# Altium schematic sheet RFID.SchDoc(RFID)
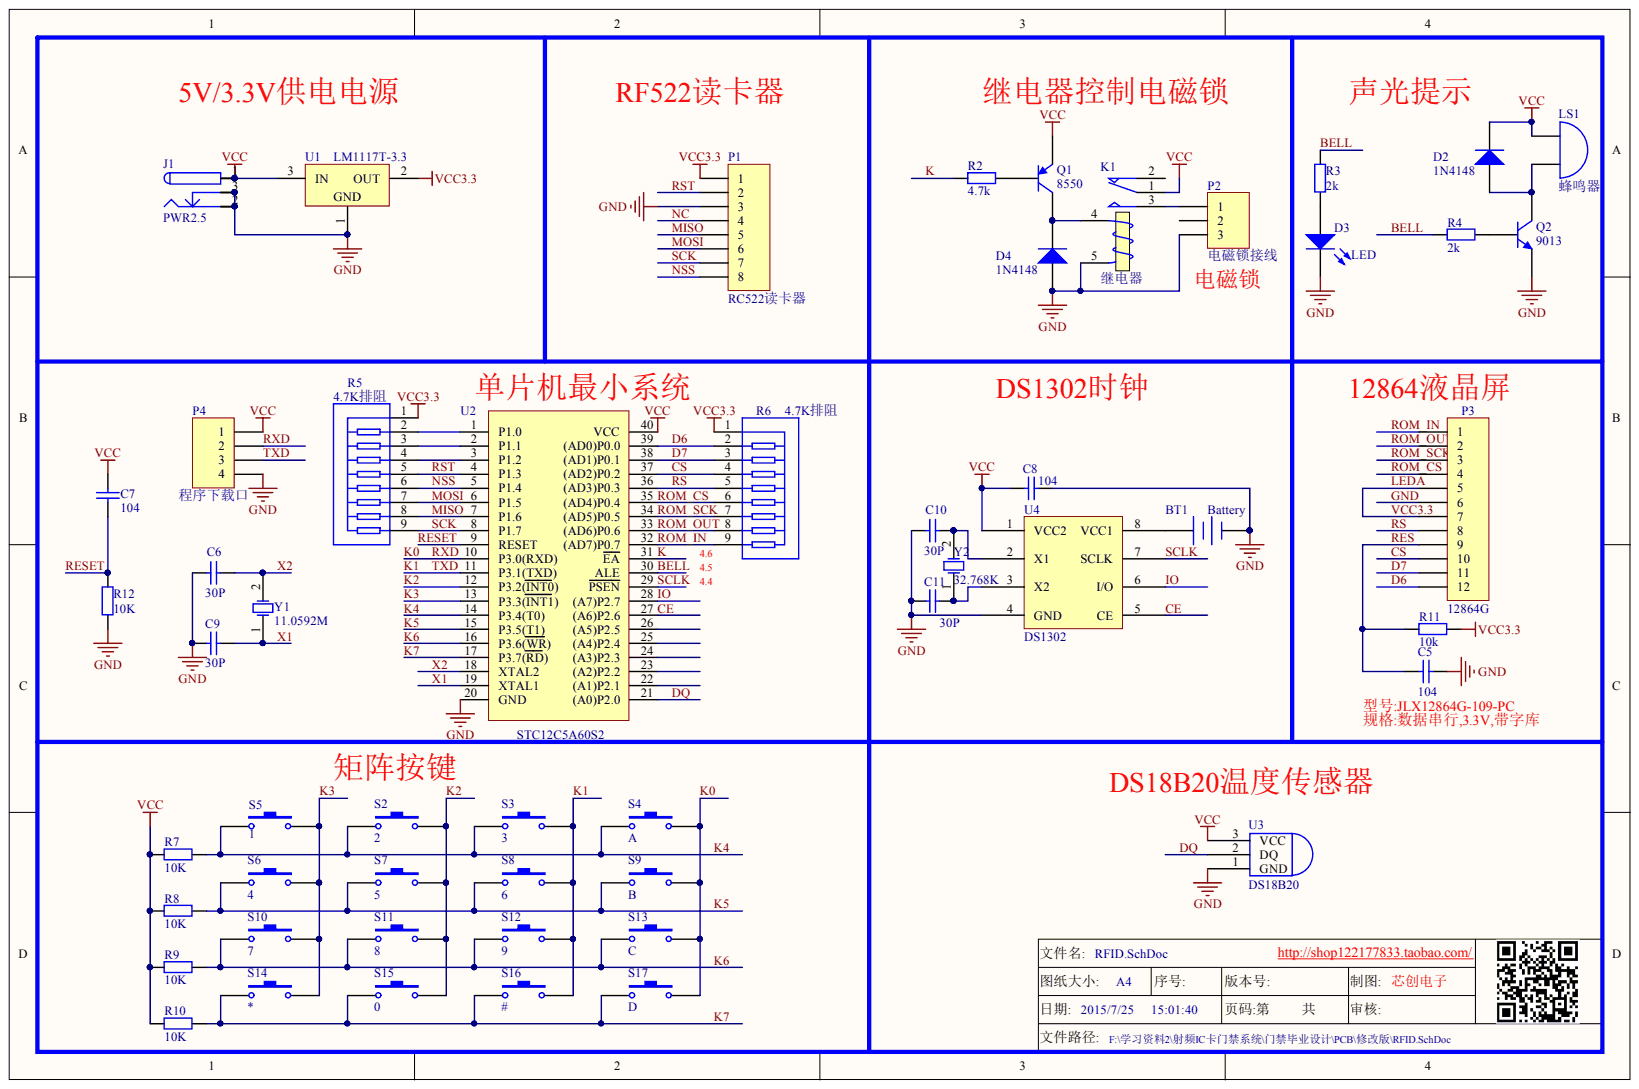
[diagram p0: RFID.SchDoc]

## Parts
BT1  Battery  [BAT-2]
C5  104  [C 0.2]
C6  30P  [C 0.1]
C7  104  [C 0.1]
C8  104  [C 0.2]
C9  30P  [C 0.1]
C10  30P  [C 0.1]
C11  30P  [C 0.1]
D2  1N4148  [DO-35]
D3  LED  [LED]
D4  1N4148  [DO-35]
J1  PWR2.5  [KLD-0202]
K1  继电器  [MODULE5A]
LS1  蜂鸣器  [buzzer]
P1  RC522读卡器  [HDR1X8]
P2  电磁锁接线  [HDR1X3]
P3  12864G  [12864G]
P4  程序下载口  [HDR1X4]
Q1  8550  [TO-92b]
Q2  9013  [TO-92b]
R2  4.7k  [AXIAL-0.4]
R3  2k  [AXIAL-0.4]
R4  2k  [AXIAL-0.4]
R5  4.7K排阻  [HDR1X9]
R6  4.7K排阻  [HDR1X9]
R7  10K  [AXIAL-0.4]
R8  10K  [AXIAL-0.4]
R9  10K  [AXIAL-0.4]
R10  10K  [AXIAL-0.4]
R11  10k  [AXIAL-0.4]
R12  10K  [AXIAL-0.4]
S2  2  [Sw-4]
S3  3  [Sw-4]
S4  A  [Sw-4]
S5  1  [Sw-4]
S6  4  [Sw-4]
S7  5  [Sw-4]
S8  6  [Sw-4]
S9  B  [Sw-4]
S10  7  [Sw-4]
S11  8  [Sw-4]
S12  9  [Sw-4]
S13  C  [Sw-4]
S14  (no value)  [Sw-4]
S15  0  [Sw-4]
S16  #  [Sw-4]
S17  D  [Sw-4]
U1  LM1117T-3.3  [T03B]
U2  STC12C5A60S2  [DIP40]
U3  DS18B20  [to-92b]
U4  DS1302  [DIP-8]
Y1  11.0592M  [XTAL]
Y2  32.768K  [r38]

## Nets
VCC: C7.2 C8.2 D2.2 J1.1 J1.1A J1.1B K1.1 LS1.1 P4.1 Q1.1 R7.2 R8.2 R9.2 R10.2 U1.3 U2.40(VCC) U3.3 U4.1(VCC2)
BELL: R3.2 R4.2 U2.30(ALE)
CE: U2.27((A6)P2.6) U4.5(CE)
CS: P3.10 R6.4 U2.37((AD2)P0.2)
D6: P3.12 R6.2 U2.39((AD0)P0.0)
D7: P3.11 R6.3 U2.38((AD1)P0.1)
DQ: U2.21((A0)P2.0) U3.2
GND: BT1.2 C5.1 C6.1 C8.1 C9.1 C10.1 C11.1 D3.2 D4.1 J1.2 J1.2A J1.2B J1.3 J1.3A J1.3B K1.5 P1.3 P2.3 P3.6 P4.4 Q2.1 R12.1 U1.1 U2.20(GND) U3.1 U4.4(GND)
IO: U2.28((A7)P2.7) U4.6(I/O)
K: R2.2 U2.31(EA)
K0: P4.2 S4.2 S9.2 S13.2 S17.2 U2.10(P3.0(RXD))
K1: P4.3 S3.2 S8.2 S12.2 S16.2 U2.11(P3.1(TXD))
K2: S2.2 S7.2 S11.2 S15.2 U2.12(P3.2(INT0))
K3: S5.2 S6.2 S10.2 S14.2 U2.13(P3.3(INT1))
K4: R7.1 S2.1 S3.1 S4.1 S5.1 U2.14(P3.4(T0))
K5: R8.1 S6.1 S7.1 S8.1 S9.1 U2.15(P3.5(T1))
K6: R9.1 S10.1 S11.1 S12.1 S13.1 U2.16(P3.6(WR))
K7: R10.1 S14.1 S15.1 S16.1 S17.1 U2.17(P3.7(RD))
LEDA: P1.1 P3.5 P3.7 R5.1 R6.1 R11.2 U1.2
MISO: P1.5 R5.8 U2.7(P1.6)
MOSI: P1.6 R5.7 U2.6(P1.5)
NC: P1.4
NSS: P1.8 R5.6 U2.5(P1.4)
RES: C5.2 P3.9 R11.1
RESET: C7.1 R12.2 U2.9(RESET)
ROM_CS: P3.4 R6.6 U2.35((AD4)P0.4)
ROM_IN: P3.1 R6.9 U2.32((AD7)P0.7)
ROM_OUT: P3.2 R6.8 U2.33((AD6)P0.6)
ROM_SCK: P3.3 R6.7 U2.34((AD5)P0.5)
RS: P3.8 R6.5 U2.36((AD3)P0.3)
RST: P1.2 R5.5 U2.4(P1.3)
SCK: P1.7 R5.9 U2.8(P1.7)
SCLK: U2.29(PSEN) U4.7(SCLK)
X1: C9.2 U2.19(XTAL1) Y1.1
X2: C6.2 U2.18(XTAL2) Y1.2
NetBT1_1: BT1.1 U4.8(VCC1)
NetC10_2: C10.2 U4.2(X1) Y2.2
NetC11_2: C11.2 U4.3(X2) Y2.1
NetD2_1: D2.1 LS1.2 Q2.3
NetD3_1: D3.1 R3.1
NetD4_2: D4.2 K1.4 Q1.3
NetK1_3: K1.3 P2.1
NetQ1_2: Q1.2 R2.1
NetQ2_2: Q2.2 R4.1
NetR5_2: R5.2 U2.1(P1.0)
NetR5_3: R5.3 U2.2(P1.1)
NetR5_4: R5.4 U2.3(P1.2)

Not connected: K1 2; P2 2; U2 22((A1)P2.1) 23((A2)P2.2) 24((A3)P2.3) 25((A4)P2.4) 26((A5)P2.5)

## Library data of the parts
BT1 = Battery: Multicell Battery; Component Kind: Standard; LatestRevisionDate: 17-Jul-2002; LatestRevisionNote: Re-released for DXP Platform.; Library Name: Miscellaneous Devices.IntLib; PackageDescription: Battery; 2 Leads; PackageReference: BAT-2; Published: 24-Mar-1999; Publisher: Altium Limited
C5-C11 = Cap: Capacitor; Component Kind: Standard; LatestRevisionDate: 17-Jul-2002; LatestRevisionNote: Re-released for DXP Platform.; Library Name: Miscellaneous Devices.IntLib; PackageDescription: Radial Cap, Thru-Hole; 2 Leads; 0.3 in Pin Spacing; PackageReference: RAD-0.3; Published: 8-Jun-2000; Signal Integrity: Cap; Simulation: CAP; Publisher: Altium Limited
D2 D4 = Diode 1N4148: High Conductance Fast Diode; Code_JEDEC: DO-204-AH; Component Kind: Standard; ComponentLink1Description: Manufacturer Link; ComponentLink1URL: http://www.fairchildsemi.com/; ComponentLink2Description: Datasheet; ComponentLink2URL: http://www.fairchildsemi.com/ds/1N%2F1N4148.pdf; DatasheetVersion: 1997; LatestRevisionDate: 17-Jul-2002; LatestRevisionNote: Re-released for DXP Platform.; Library Name: Miscellaneous Devices.IntLib; PackageDescription: Diode, Axial; Body 3.8 x 1.9 mm (LxDia. max), Lead Dia. 0.53 mm (max); PackageReference: DO-35; Published: 8-Jun-2000; Signal Integrity: 1N4148; Simulation: 1N4148; Publisher: Altium Limited
D3 = LED0: Typical INFRARED GaAs LED; Component Kind: Standard; LatestRevisionDate: 08-Jul-2005; LatestRevisionNote: Mech Layer 1 removed.; Library Name: Miscellaneous Devices.IntLib; PackageDescription: LED; 2 Leads; PackageReference: LED-0; Published: 8-Jun-2000; Simulation: LED0; Publisher: Altium Limited
J1 = PWR2.5: Low Voltage Power Supply Connector; Component Kind: Standard; ComponentLink1Description: Manufacturer Link; ComponentLink1URL: http://domino.kycon.com/internet/Products.html; DatasheetDocument: 09-May-2002; Library Name: Miscellaneous Connectors.IntLib; Published: 17-Dec-2003; Signal Integrity: Connector; Publisher: Altium Limited
K1 = Relay: SPDT Relay; Component Kind: Standard; LatestRevisionDate: 17-Jul-2002; LatestRevisionNote: Re-released for DXP Platform.; Library Name: Miscellaneous Devices.IntLib; PackageReference: MODULE5B; Published: 8-Jun-2000; Simulation: SPDTRELAY; Publisher: Altium Limited
LS1 = Bell: Electrical Bell; Component Kind: Standard; LatestRevisionDate: 17-Jul-2002; LatestRevisionNote: Re-released for DXP Platform.; Library Name: Miscellaneous Devices.IntLib; PackageReference: PIN2; Published: 24-Mar-1999; Publisher: Altium Limited
P1 = Header 8: Header, 8-Pin; Component Kind: Standard; LatestRevisionDate: 17-Jul-2002; LatestRevisionNote: Re-released for DXP Platform.; Library Name: Miscellaneous Connectors.IntLib; Signal Integrity: Connector; Publisher: Altium Limited
P2 = Header 3: Header, 3-Pin; Component Kind: Standard; LatestRevisionDate: 17-Jul-2002; LatestRevisionNote: Re-released for DXP Platform.; Library Name: Miscellaneous Connectors.IntLib; Signal Integrity: Connector; Publisher: Altium Limited
P3 = Header 12: Header, 12-Pin; Component Kind: Standard; LatestRevisionDate: 17-Jul-2002; LatestRevisionNote: Re-released for DXP Platform.; Library Name: Miscellaneous Connectors.IntLib; Signal Integrity: Connector; Publisher: Altium Limited
P4 = Header 4: Header, 4-Pin; Component Kind: Standard; LatestRevisionDate: 17-Jul-2002; LatestRevisionNote: Re-released for DXP Platform.; Library Name: Miscellaneous Connectors.IntLib; Signal Integrity: Connector; Publisher: Altium Limited
Q1 = 2N3906: PNP General Purpose Amplifier; Component Kind: Standard; ComponentLink1Description: Manufacturer Link; ComponentLink1URL: http://www.fairchildsemi.com/; ComponentLink2Description: Datasheet; ComponentLink2URL: http://www.fairchildsemi.com/ds/2N%2F2N3906.pdf; DatasheetVersion: 1997; LatestRevisionDate: 15-Jan-2003; LatestRevisionNote: PCB Footprint 'BCY-W3/D4.7' replaced; Library Name: Miscellaneous Devices.IntLib; PackageDescription: TO, Flat Index; 3 In-Line, Axial Leads; Body Dia. 4.5mm; Leads 0.51 x 0.48 mm (max); PackageReference: TO-92A; PackageVersion: Sep-1998; Published: 8-Jun-2000; Signal Integrity: 2N3906; Simulation: 2N3906; Publisher: Altium Limited
Q2 = 2N3904: NPN General Purpose Amplifier; Component Kind: Standard; ComponentLink1Description: Manufacturer Link; ComponentLink1URL: http://www.fairchildsemi.com/; ComponentLink2Description: Datasheet; ComponentLink2URL: http://www.fairchildsemi.com/ds/2N%2F2N3904.pdf; DatasheetVersion: 1997; LatestRevisionDate: 15-Jan-2003; LatestRevisionNote: PCB Footprint 'BCY-W3/D4.7' replaced; Library Name: Miscellaneous Devices.IntLib; PackageDescription: TO, Flat Index; 3 In-Line, Axial Leads; Body Dia. 4.5mm; Leads 0.51 x 0.48 mm (max); PackageReference: TO-92A; PackageVersion: Sep-1998; Published: 8-Jun-2000; Signal Integrity: 2N3904; Simulation: 2N3904; Publisher: Altium Limited
R2 R4 R7-R10 R12 = Res2: Resistor; Component Kind: Standard; LatestRevisionDate: 17-Jul-2002; LatestRevisionNote: Re-released for DXP Platform.; Library Name: Miscellaneous Devices.IntLib; PackageDescription: Axial Device, Thru-Hole; 2 Leads; 0.4 in Pin Spacing; PackageReference: AXIAL-0.4; Published: 8-Jun-2000; Signal Integrity: Res; Simulation: RESISTOR; Publisher: Altium Limited
R3 R11 = Res2: Resistor; Value: 1K; Component Kind: Standard; LatestRevisionDate: 17-Jul-2002; LatestRevisionNote: Re-released for DXP Platform.; Library Name: Miscellaneous Devices.IntLib; PackageDescription: Axial Device, Thru-Hole; 2 Leads; 0.4 in Pin Spacing; PackageReference: AXIAL-0.4; Published: 8-Jun-2000; Signal Integrity: Res; Simulation: RESISTOR; Publisher: Altium Limited
R5 R6 = RESX8: Component Kind: Standard; Library Name: 自制.SchLib
S2-S17 = SW-PB: Switch; Component Kind: Standard; LatestRevisionDate: 17-Jul-2002; LatestRevisionNote: Re-released for DXP Platform.; Library Name: Miscellaneous Devices.IntLib; PackageDescription: Switch; 2 Leads; PackageReference: SPST-2; Published: 24-Mar-1999; Publisher: Altium Limited
U1 = LM1117T-3.3: 800mA Low-Dropout Linear Regulator, 3-pin TO-220; Manufacturer: National Semiconductor; CompType: LDO IC; PackageTopMarking: NSUZXYTTE#*LM1117T*3.3; PowerWise: N; Component Kind: Standard; ComponentLink1Description: LM1117 Datasheet; ComponentLink1URL: http://www.national.com/ds/LM/LM1117.pdf; ComponentLink2Description: LM1117 Web Page; ComponentLink2URL: http://www.national.com/pf/LM/LM1117.html; ComponentLink3Description: T03B Package Page; ComponentLink3URL: http://www.national.com/packaging/folders/t03b.html; DatasheetVersion: Apr-2006; Library Name: NSC LDO.IntLib; PackageDescription: TO-220, Molded, 3 Lead, Straight; PackageReference: T03B; PackageVersion: revL, Jan-1992; PartNumber: LM1117T-3.3; PinCount: 3; Published: 4-Dec-2008; RoHS: N; Supplier 1: Farnell; Supplier Part Number 1: 9485813; Publisher: Altium Limited
U2 = AT89S52: Component Kind: Standard; Library Name: 自制.SchLib
U3 = DS18B02: Component Kind: Standard; Library Name: 自制.SchLib
Y1 Y2 = XTAL: Crystal Oscillator; Component Kind: Standard; LatestRevisionDate: 17-Jul-2002; LatestRevisionNote: Re-released for DXP Platform.; Library Name: Miscellaneous Devices.IntLib; PackageDescription: Crystal, Thru-Hole; 2 Leads; Body 3.1 x 8.2 mm (Dia.xH); PackageReference: R38; Published: 8-Jun-2000; Simulation: XTAL; Publisher: Altium Limited
U4 = DS1302: Component Kind: Standard; Library Name: 自制.SchLib
